# Altium schematic sheet ARDUINORACHX.SchDoc(ARDUINORACHX)
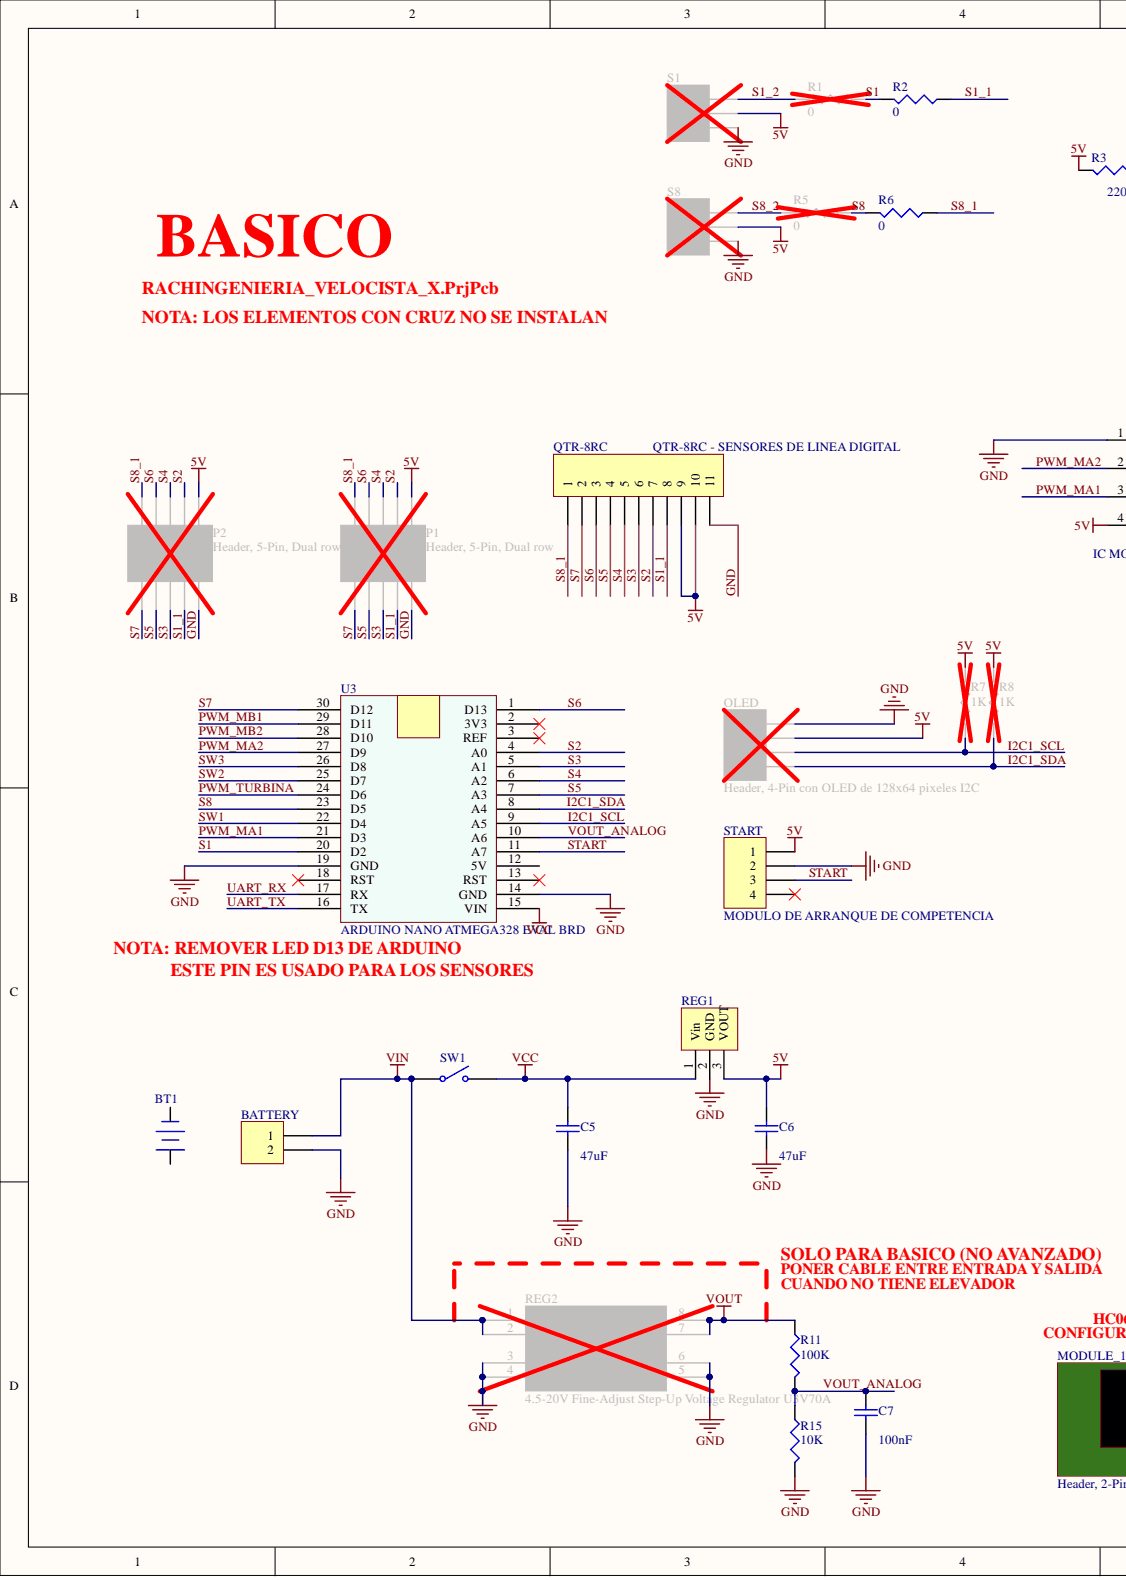
[diagram p0: ARDUINORACHX.SchDoc, left]
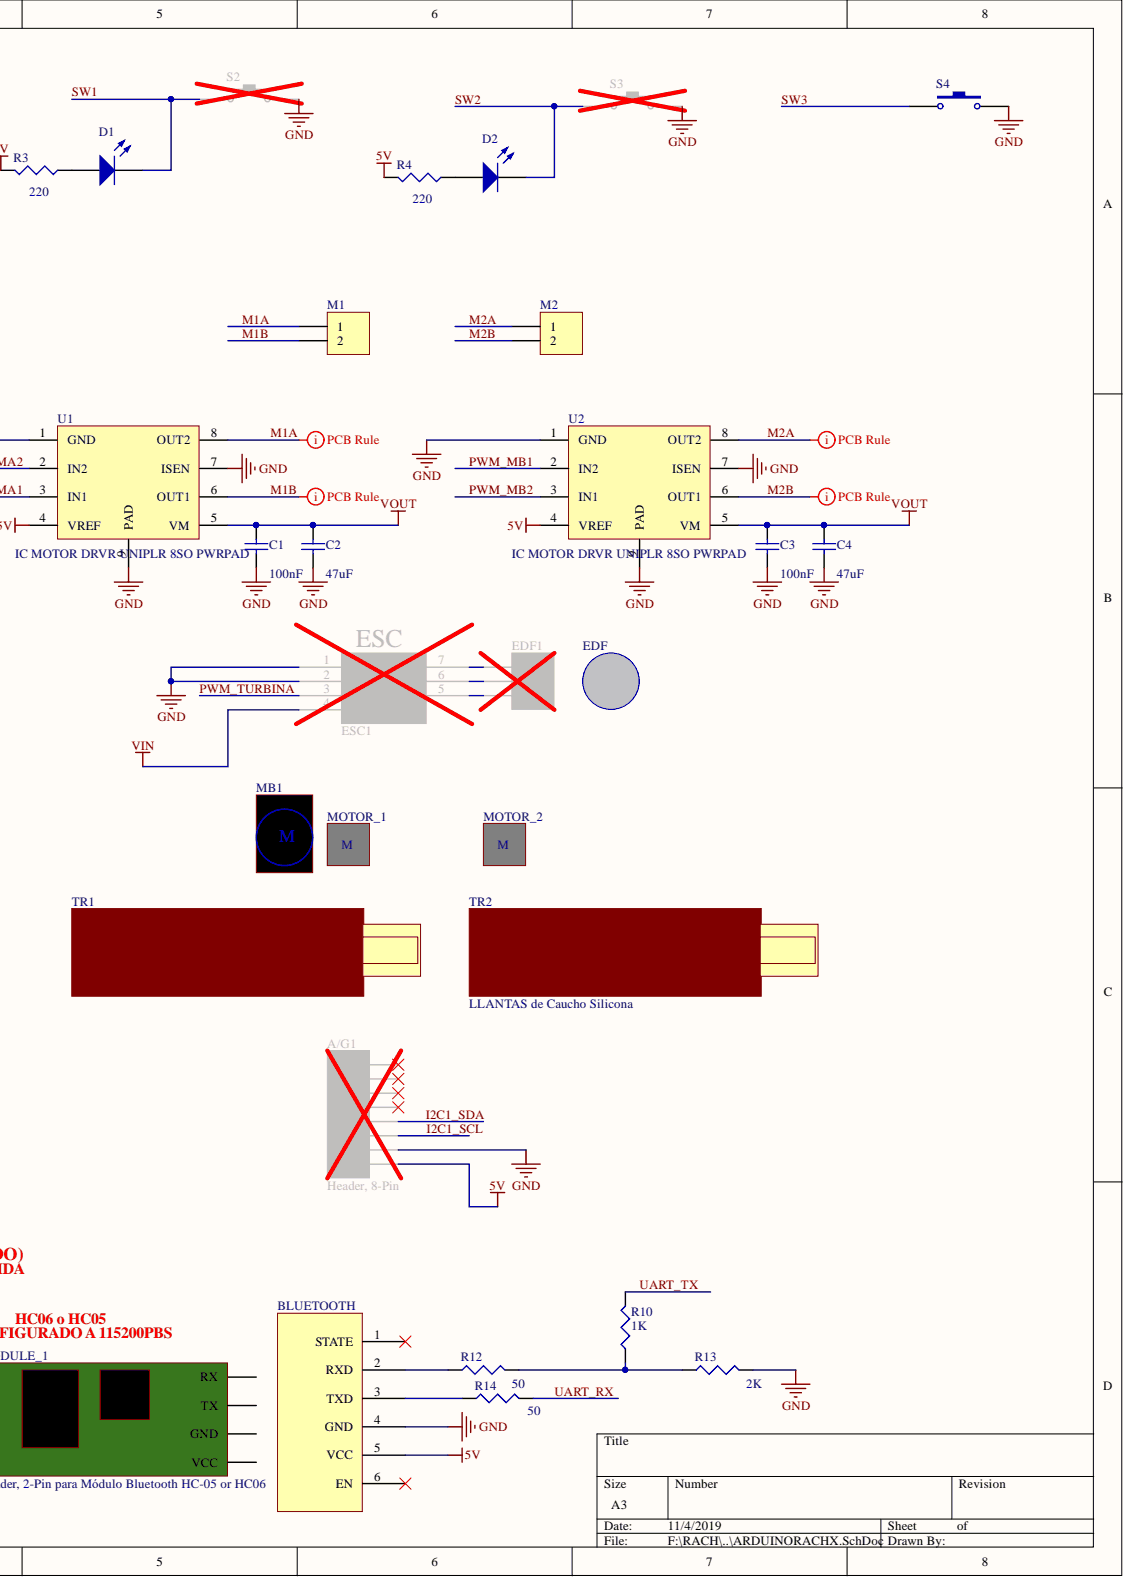
[diagram p1: ARDUINORACHX.SchDoc, right]

## Parts
A/G1  Header, 8-Pin  [ACELEROMETRO]
BATTERY  CONN HEADER VERT 2POS  [HDR1X2]  (value not drawn)
BLUETOOTH  Módulo Bluetooth HC-05 or HC06  [HDR1X6 BT]
BT1  BATERIA LIPO 2s o 3s a 300maH a 2000mAh  [BATERIA]  (value not drawn)
C1  value 100nF  [CC0805]  (hidden comment: CAP CER 0.1UF 50V X7R 0805)
C2  value 47uF  [C1210]  (hidden comment: CAP CER 47UF 25V X5R 1210)
C3  value 100nF  [CC0805]  (hidden comment: CAP CER 0.1UF 50V X7R 0805)
C4  value 47uF  [C1210]  (hidden comment: CAP CER 47UF 25V X5R 1210)
C5  value 47uF  [C1210]  (hidden comment: CAP CER 47UF 25V X5R 1210)
C6  value 47uF  [C1210]  (hidden comment: CAP CER 47UF 25V X5R 1210)
C7  value 100nF  [CC0805]  (hidden comment: CAP CER 0.1UF 50V X7R 0805)
D1  LED RED CLEAR 1206 SMD  [DSO-F2/D6.1]  (value not drawn)
D2  LED RED CLEAR 1206 SMD  [DSO-F2/D6.1]  (value not drawn)
EDF  EDF35 with 11000kv Motor Assembled  [ESC27]  (value not drawn)
EDF1  TURBINA DE 27mm Brushless  [HDR1X3]  (value not drawn)
ESC1  SPEED CONTROLLER de 6 o mas Amperios  [ESC]  (value not drawn)
M1  Pines para Motor  [HDR1X2]  (value not drawn)
M2  Pines para Motor  [HDR1X2]  (value not drawn)
MB1  Pololu Micro Metal Gearmotor Bracket Pair - Black  [TURBINA]  (value not drawn)  (mechanical)
MODULE_1  Header, 2-Pin para Módulo Bluetooth HC-05 or HC06  (mechanical)
MOTOR_1  10:1 Micro Metal Gearmotor HPCB 6V -3000rpm  [MOTORES]  (value not drawn)
MOTOR_2  10:1 Micro Metal Gearmotor HPCB 6V -3000rpm  [MOTORES]  (value not drawn)
OLED  Header, 4-Pin con OLED de 128x64 pixeles I2C  [HDR1X4 OLED]
P1  Header, 5-Pin, Dual row  [HDR2X5]
P2  Header, 5-Pin, Dual row  [HDR2X5]
QTR-8RC  QTR-8RC - SENSORES DE LINEA DIGITAL  [QTR-8RC]
R1  value 0  [CC0805]  (hidden comment: RES SMD 0 OHM 1% 0.4W 0805)
R2  value 0  [CC0805]  (hidden comment: RES SMD 0 OHM 1% 0.4W 0805)
R3  value 220  [CC0805]  (hidden comment: RES SMD 220 OHM 1% 0.4W 0805)
R4  value 220  [CC0805]  (hidden comment: RES SMD 220 OHM 1% 0.4W 0805)
R5  value 0  [CC0805]  (hidden comment: RES SMD 0 OHM 1% 0.4W 0805)
R6  value 0  [CC0805]  (hidden comment: RES SMD 0 OHM 1% 0.4W 0805)
R7  value 1K  [CC0805]  (hidden comment: RES SMD 1K OHM 0.1% 1/8W 0805)
R8  value 1K  [CC0805]  (hidden comment: RES SMD 1K OHM 0.1% 1/8W 0805)
R10  value 1K  [CC0805]  (hidden comment: RES SMD 1K OHM 0.1% 1/8W 0805)
R11  value 100K  [CC0805]  (hidden comment: RES SMD 100K OHM 0.1% 1/8W 0805)
R12  value 50  [CC0805]  (hidden comment: RES SMD 50 OHM 0.05% 1/5W 0805)
R13  value 2K  [CC0805]  (hidden comment: RES SMD 2K OHM 0.1% 1/8W 0805)
R14  value 50  [CC0805]  (hidden comment: RES SMD 50 OHM 0.05% 1/5W 0805)
R15  value 10K  [CC0805]  (hidden comment: RES SMD 10K OHM 1% 0.4W 0805)
REG1  DC DC CONVERTER 5V OR -5V o 7805  [VXO7805]  (value not drawn)
REG2  4.5-20V Fine-Adjust Step-Up Voltage Regulator U3V70A  [StepUpVoltage]
S1  Sensor QTR1 DIGITAL  [HDR1X3]  (value not drawn)
S2  PULSADOR - SWITCH TACTILE SPST-NO 0.05A 12V  [1571563-9]  (value not drawn)
S3  PULSADOR - SWITCH TACTILE SPST-NO 0.05A 12V  [1571563-9]  (value not drawn)
S4  PULSADOR - SWITCH TACTILE SPST-NO 0.05A 12V  [1571563-9]  (value not drawn)
S8  Sensor QTR1 DIGITAL  [HDR1X3]  (value not drawn)
START  MODULO DE ARRANQUE DE COMPETENCIA  [start_module]
SW1  Mini Switch de dos Posiciones  [OS102011MS2QN1]  (value not drawn)
TR1  LLANTAS de Caucho Silicona  (mechanical)
TR2  LLANTAS de Caucho Silicona  (mechanical)
U1  IC MOTOR DRVR UNIPLR 8SO PWRPAD  [SOIC127P600X170_HS-9N]
U2  IC MOTOR DRVR UNIPLR 8SO PWRPAD  [SOIC127P600X170_HS-9N]
U3  ARDUINO NANO ATMEGA328 EVAL BRD  [ARDUINO]

## Nets
5V: A/G1.1 BLUETOOTH.5(VCC) C6.2 OLED.3 P1.10 P2.10 QTR-8RC.9 QTR-8RC.10 R3.2 R4.2 R7.2 R8.2 REG1.3 S1.2 S8.2 START.1 U1.4(VREF) U2.4(VREF)
VCC: C5.2 REG1.1 SW1.2 U3.15(VIN)
VIN: BATTERY.1 ESC1.4(GND) REG2.1(VIIN) REG2.2(VIIN) SW1.1
VOUT: C1.2 C2.2 C3.2 C4.2 R11.1 REG2.7(VOUT) REG2.8(VOUT) U1.5(VM) U2.5(VM)
GND: A/G1.2 BATTERY.2 BLUETOOTH.4(GND) C1.1 C2.1 C3.1 C4.1 C5.1 C6.1 C7.1 ESC1.1(VIN) ESC1.2(GND) OLED.4 P1.9 P2.9 QTR-8RC.11 R13.2 R15.2 REG1.2 REG2.3(GND) REG2.4(GND) REG2.5(GND) REG2.6(GND) S1.1 S2.2 S3.2 S4.2 S8.1 START.2 U1.1(GND) U1.7(ISEN) U1.9(PAD) U2.1(GND) U2.7(ISEN) U2.9(PAD) U3.14(GND) U3.19(GND)
I2C1_SCL: A/G1.3 OLED.2 R7.1 U3.9(A5)
I2C1_SDA: A/G1.4 OLED.1 R8.1 U3.8(A4)
M1A: M1.1 U1.8(OUT2)
M1B: M1.2 U1.6(OUT1)
M2A: M2.1 U2.8(OUT2)
M2B: M2.2 U2.6(OUT1)
PWM_MA1: U1.3(IN1) U3.21(D3)
PWM_MA2: U1.2(IN2) U3.27(D9)
PWM_MB1: U2.2(IN2) U3.29(D11)
PWM_MB2: U2.3(IN1) U3.28(D10)
PWM_TURBINA: ESC1.3(S) U3.24(D6)
S1: R1.2 R2.2 U3.20(D2)
S1_1: P1.7 P2.7 QTR-8RC.8 R2.1
S1_2: R1.1 S1.3
S2: P1.8 P2.8 QTR-8RC.7 U3.4(A0)
S3: P1.5 P2.5 QTR-8RC.6 U3.5(A1)
S4: P1.6 P2.6 QTR-8RC.5 U3.6(A2)
S5: P1.3 P2.3 QTR-8RC.4 U3.7(A3)
S6: P1.4 P2.4 QTR-8RC.3 U3.1(D13)
S7: P1.1 P2.1 QTR-8RC.2 U3.30(D12)
S8: R5.2 R6.2 U3.23(D5)
S8_1: P1.2 P2.2 QTR-8RC.1 R6.1
S8_2: R5.1 S8.3
START: START.3 U3.11(A7)
SW1: D1.2 S2.1 U3.22(D4)
SW2: D2.2 S3.1 U3.25(D7)
SW3: S4.1 U3.26(D8)
UART_RX: R14.2 U3.17(RX)
UART_TX: R10.2 U3.16(TX)
VOUT_ANALOG: C7.2 R11.2 R15.1 U3.10(A6)
NetBLUETOOTH_2: BLUETOOTH.2(RXD) R12.1
NetBLUETOOTH_3: BLUETOOTH.3(TXD) R14.1
NetD1_1: D1.1 R3.1
NetD2_1: D2.1 R4.1
NetEDF1_1: EDF1.1 ESC1.7(X)
NetEDF1_2: EDF1.2 ESC1.6(W)
NetEDF1_3: EDF1.3 ESC1.5(Y)
NetR10_1: R10.1 R12.2 R13.1

Not connected: A/G1 5 6 7 8; BLUETOOTH 1(STATE) 6(EN); MODULE_1 1(RX) 2(TX) 3(GND) 4(VCC); START 4; U3 2(3V3) 3(REF) 12(5V) 13(RST) 18(RST)

## Library data of the parts
A/G1 = Header 8: Manufacturer PN: IMU I2C; Special Notes: OPTIONAL; Signal Integrity: Connector; Manufacturer Part Number 1: IMU I2C; Description: Header, 8-Pin; Component Kind: Standard; Library Name: VELTRONICS.SCHLIB
BATTERY = Header 2: Manufacturer PN: TSW-101-05-G-D; Manufacturer 1: Samtec Inc.; Supplier Part Number 1: SAM1012-01-ND; Supplier 1: Digi-key; Supplier: Digi-key; Signal Integrity: Connector; Manufacturer Part Number 1: TSW-101-05-G-D; Manufacturer: Samtec Inc.; Description: CONN HEADER VERT 2POS; Component Kind: Standard; Library Name: VELTRONICS.SCHLIB
BLUETOOTH = Bluetooth_HC05: CONN HDR 6POS 0.1 GOLD PCB; Manufacturer PN: PPPC061LFBN-RC; Manufacturer 1: Sullins Connector Solutions; Supplier Part Number 1: S7039-ND; Supplier 1: Digi-key; Supplier: Digi-key; Manufacturer Part Number 1: PPPC061LFBN-RC; Manufacturer: Sullins Connector Solutions; Component Kind: Standard; Library Name: VELTRONICS.SCHLIB
BT1 = Battery: Manufacturer PN: LIPO 2S 1000mA; Manufacturer 1: JJTRONICS; Supplier Part Number 1: LIPO 2S 1000mA; Supplier 1: JJTRONICS; Supplier: JJTRONICS; Manufacturer Part Number 1: LIPO 2S 1000mA; Manufacturer: JJTRONICS; Description: BATERIA LIPO 2s o 3s a 300maH a 2000mAh; Component Kind: Standard; Library Name: VELTRONICS.SCHLIB
C1 C3 C7 = Cap: Manufacturer PN: C0805C104K5RACTU; Manufacturer 1: KEMET; Supplier Part Number 1: 399-1170-1-ND; Supplier 1: Digi-key; Supplier: Digi-key; Simulation: CAP; Signal Integrity: Cap; Manufacturer Part Number 1: C0805C104K5RACTU; Manufacturer: KEMET; Description: CAP CER 0.1UF 50V X7R 0805; Component Kind: Standard; Library Name: VELTRONICS.SCHLIB
C2 C4-C6 = Cap: Manufacturer PN: TMK325ABJ476MM-P; Manufacturer 1: Taiyo Yuden; Supplier Part Number 1: 587-5479-1-ND; Supplier 1: Digi-key; Supplier: Digi-key; Simulation: CAP; Signal Integrity: Cap; Manufacturer Part Number 1: TMK325ABJ476MM-P; Manufacturer: Taiyo Yuden; Description: CAP CER 47UF 25V X5R 1210; Component Kind: Standard; Library Name: VELTRONICS.SCHLIB
D1 D2 = LED2: Manufacturer PN: AOL-S12RP4; Manufacturer 1: Digi-key; Manufacturer: Visual Communications Company - VCC; Supplier Part Number 1: VAOL-S12RP4CT-ND; Supplier 1: Digi-key; Supplier: Digi-key; Simulation: LED2; Manufacturer Part Number 1: AOL-S12RP4; Description: LED RED CLEAR 1206 SMD; Component Kind: Standard; Library Name: VELTRONICS.SCHLIB
EDF = EDF TURBINA: Manufacturer 1: HobbyKing; Supplier Part Number 1: EDF35 with 11000kv Motor Assembled; Supplier 1: HobbyKing; Supplier: HobbyKing; Manufacturer PN: EDF35 with 11000kv Motor Assembled; Manufacturer Part Number 1: EDF35 with 11000kv Motor Assembled; Manufacturer: HobbyKing; Description: EDF35 with 11000kv Motor Assembled; Component Kind: Standard; Library Name: VELTRONICS.SCHLIB
EDF1 S1 S8 = Header 3: Special Notes: Not Part; Signal Integrity: Connector; Manufacturer PN: Not Part; Manufacturer Part Number 1: Not Part; Component Kind: Standard; Library Name: VELTRONICS.SCHLIB
  EDF1: Description: TURBINA DE 27mm Brushless
  S1 S8: Description: Sensor QTR1 DIGITAL
ESC1 = ESC_30: Manufacturer PN: ESC 20A Mini; Manufacturer 1: JJTRONICS; Supplier Part Number 1: ESC 20A Mini; Supplier 1: JJTRONICS; Supplier: JJTRONICS; Manufacturer Part Number 1: ESC 20A Mini; Manufacturer: JJTRONICS; Description: SPEED CONTROLLER de 6 o mas Amperios; Component Kind: Standard; Library Name: RACHINGENIERIA_VELOCISTA_X.SCHLIB
M1 M2 = Header 2: Special Notes: Not Part; Signal Integrity: Connector; Manufacturer PN: Not Part; Manufacturer Part Number 1: Not Part; Description: Pines para Motor; Component Kind: Standard; Library Name: VELTRONICS.SCHLIB
MB1 = MotorBrakets: Manufacturer PN: 989; Supplier Part Number 1: 989; Supplier 1: POLOLU; Supplier: POLOLU; Manufacturer Part Number 1: 989; Manufacturer 1: POLOLU; Manufacturer: POLOLU; Description: Pololu Micro Metal Gearmotor Bracket Pair - Black; Library Name: VELTRONICS.SCHLIB
MODULE_1 = HC05: Módulo Bluetooth HC-05 or HC06; Manufacturer PN: HC-05 / HC06; Manufacturer 1: JJTRONICS; Supplier Part Number 1: HC-05 / HC06; Supplier 1: JJTRONICS; Supplier: JJTRONICS; Signal Integrity: Connector; Manufacturer Part Number 1: HC-05 / HC06; Manufacturer: JJTRONICS; Library Name: VELTRONICS.SCHLIB
MOTOR_1 MOTOR_2 = MOTORES: Manufacturer PN: 236; Manufacturer 1: POLOLU; Supplier Part Number 1: 236; Supplier 1: POLOLU; Supplier: POLOLU; Signal Integrity: Connector; Manufacturer Part Number 1: 236; Manufacturer: POLOLU; Description: 10:1 Micro Metal Gearmotor HPCB 6V -3000rpm; Component Kind: Standard; Library Name: VELTRONICS.SCHLIB
OLED = Header 4: Manufacturer PN: OLED I2C; Manufacturer 1: JJTRONICS; Signal Integrity: Connector; Manufacturer Part Number 1: OLED I2C; Manufacturer: JJTRONICS; Description: Header, 4-Pin con OLED de 128x64 pixeles I2C; Component Kind: Standard; Library Name: VELTRONICS.SCHLIB
P1 P2 = Header 5X2: Special Notes: OPTIONAL; Signal Integrity: Connector; Manufacturer PN: HDR2X5; Manufacturer Part Number 1: HDR2X5; Description: Header, 5-Pin, Dual row; Component Kind: Standard; Library Name: VELTRONICS.SCHLIB
QTR-8RC = Header 11H: Manufacturer 1: JJTRONICS; Supplier Part Number 1: QTR-8RC; Supplier 1: JJTRONICS; Supplier: JJTRONICS; Signal Integrity: Connector; Manufacturer PN: QTR-8RC; Manufacturer Part Number 1: QTR-8RC; Manufacturer: JJTRONICS; Description: QTR-8RC - SENSORES DE LINEA DIGITAL; Component Kind: Standard; Library Name: VELTRONICS.SCHLIB
R1 R2 R5 R6 = Res3: Manufacturer PN: ESR10EZPF0; Manufacturer 1: Rohm Semiconductor; Manufacturer: Resistencia; Supplier Part Number 1: RHM0AECT-ND; Supplier 1: Digi-key; Supplier: Digi-key; Simulation: RESISTOR; Signal Integrity: Res; Manufacturer Part Number 1: ESR10EZPF0; Description: RES SMD 0 OHM 1% 0.4W 0805; Component Kind: Standard; Library Name: VELTRONICS.SCHLIB
R3 R4 = Res3: Manufacturer PN: ESR10EZPF2200; Manufacturer 1: Rohm Semiconductor; Manufacturer: Resistencia; Supplier Part Number 1: RHM220AECT-ND; Supplier 1: Digi-key; Supplier: Digi-key; Simulation: RESISTOR; Signal Integrity: Res; Manufacturer Part Number 1: ESR10EZPF2200; Description: RES SMD 220 OHM 1% 0.4W 0805; Component Kind: Standard; Library Name: VELTRONICS.SCHLIB
R7 R8 R10 = Res3: Manufacturer PN: ERA-6AEB102V; Manufacturer 1: Panasonic Electronic Components; Manufacturer: Resistencia; Supplier Part Number 1: P1.0KDACT-ND; Supplier 1: Digi-key; Supplier: Digi-key; Simulation: RESISTOR; Signal Integrity: Res; Manufacturer Part Number 1: ERA-6AEB102V; Description: RES SMD 1K OHM 0.1% 1/8W 0805; Component Kind: Standard; Library Name: VELTRONICS.SCHLIB
R11 = Res3: Manufacturer PN: ERA-6AEB104V; Manufacturer 1: Panasonic Electronic Components; Manufacturer: Resistencia; Supplier Part Number 1: P100KDACT-ND; Supplier 1: Digi-key; Supplier: Digi-key; Simulation: RESISTOR; Signal Integrity: Res; Manufacturer Part Number 1: ERA-6AEB104V; Description: RES SMD 100K OHM 0.1% 1/8W 0805; Component Kind: Standard; Library Name: VELTRONICS.SCHLIB
R12 = Res3: Manufacturer PN: Y402250R0000A9R; Manufacturer 1: Rohm Semiconductor; Manufacturer: Resistencia; Supplier Part Number 1: 804-1106-1-ND; Supplier 1: Digi-key; Supplier: Digi-key; Simulation: RESISTOR; Signal Integrity: Res; Manufacturer Part Number 1: Y402250R0000A9R; Description: RES SMD 50 OHM 0.05% 1/5W 0805; Component Kind: Standard; Library Name: VELTRONICS.SCHLIB
R13 = Res3: Manufacturer PN: ERA-6AEB202V; Manufacturer 1: Panasonic Electronic Components; Manufacturer: Resistencia; Supplier Part Number 1: P2.0KDACT-ND; Supplier 1: Digi-key; Supplier: Digi-key; Simulation: RESISTOR; Signal Integrity: Res; Manufacturer Part Number 1: ERA-6AEB202V; Description: RES SMD 2K OHM 0.1% 1/8W 0805; Component Kind: Standard; Library Name: VELTRONICS.SCHLIB
R14 = Res3: Manufacturer PN: Y402250R0000A9R; Manufacturer 1: Vishay Foil Resistors (Division of Vishay Precision Group); Manufacturer: Resistencia; Supplier Part Number 1: 804-1106-1-ND; Supplier 1: Digi-key; Supplier: Digi-key; Simulation: RESISTOR; Signal Integrity: Res; Manufacturer Part Number 1: Y402250R0000A9R; Description: RES SMD 50 OHM 0.05% 1/5W 0805; Component Kind: Standard; Library Name: VELTRONICS.SCHLIB
R15 = Res3: Manufacturer PN: ERA-6AEB103V; Manufacturer 1: Panasonic Electronic Components; Manufacturer: Resistencia; Supplier Part Number 1: P10KDACT-ND; Supplier 1: Digi-key; Supplier: Digi-key; Simulation: RESISTOR; Signal Integrity: Res; Manufacturer Part Number 1: ERA-6AEB103V; Description: RES SMD 10K OHM 1% 0.4W 0805; Component Kind: Standard; Library Name: VELTRONICS.SCHLIB
REG1 = VxO7805: Manufacturer PN: VXO7805-500; Manufacturer 1: CUI Inc.; Supplier Part Number 1: 102-4249-ND; Supplier 1: Digi-Key; Supplier: Digi-Key; Signal Integrity: Connector; Manufacturer Part Number 1: VXO7805-500; Manufacturer: CUI Inc.; Description: DC DC CONVERTER 5V OR -5V o 7805; Component Kind: Standard; Library Name: VELTRONICS.SCHLIB
REG2 = StepUp: Manufacturer PN: 2890; Manufacturer 1: POLOLU; Supplier Part Number 1: 2890; Supplier 1: POLOLU; Supplier: POLOLU; Manufacturer Part Number 1: 2890; Manufacturer: POLOLU; Description: 4.5-20V Fine-Adjust Step-Up Voltage Regulator U3V70A; Component Kind: Standard; Library Name: VELTRONICS.SCHLIB
S2-S4 = SW-PB: Manufacturer PN: TL59NF160Q; Manufacturer 1: E-Switch; Supplier Part Number 1: EG2532CT-ND; Supplier 1: Digi-key; Supplier: Digi-key; Manufacturer Part Number 1: TL59NF160Q; Manufacturer: E-Switch; Description: PULSADOR - SWITCH TACTILE SPST-NO 0.05A 12V; Component Kind: Standard; Library Name: VELTRONICS.SCHLIB
START = Header 4: Manufacturer PN: START ROBOT MODULE; Manufacturer 1: JJTRONICS; Supplier Part Number 1: START ROBOT MODULE; Supplier 1: JJTRONICS; Supplier: JJTRONICS; Signal Integrity: Connector; Manufacturer Part Number 1: START ROBOT MODULE; Manufacturer: JJTRONICS; Description: MODULO DE ARRANQUE DE COMPETENCIA; Component Kind: Standard; Library Name: VELTRONICS.SCHLIB
SW1 = SW-SPST: Manufacturer PN: SS-12D07-VG 4 NS GA PA; Manufacturer 1: C&K; Supplier Part Number 1: CKN10397-ND; Supplier 1: Digi-key; Supplier: Digi-key; Manufacturer Part Number 1: SS-12D07-VG 4 NS GA PA; Manufacturer: C&K; Description: Mini Switch de dos Posiciones; Component Kind: Standard; Library Name: VELTRONICS.SCHLIB
TR1 TR2 = LLANTAS: Manufacturer PN: R2cmx3cm; Manufacturer 1: Rachingenieria.x; Supplier Part Number 1: R2cmx3cm; Supplier 1: Rachingenieria.x; Supplier: Rachingenieria.x; Manufacturer Part Number 1: R2cmx3cm; Manufacturer: LLANTAS; Description: LLANTAS de Caucho Silicona; Library Name: VELTRONICS.SCHLIB
U1 U2 = DRV8870: Manufacturer PN: DRV8870DDAR; Manufacturer 1: Texas Instruments; Supplier Part Number 1: 296-42660-1-ND; Supplier 1: Digi-key; Supplier: Digi-key; Manufacturer Part Number 1: DRV8870DDAR; Manufacturer: Texas Instruments; Description: IC MOTOR DRVR UNIPLR 8SO PWRPAD; Component Kind: Standard; Library Name: VELTRONICS.SCHLIB
U3 = ARDUINO NANO: Manufacturer PN: A000005; Supplier Part Number 1: 1050-1001-ND; Supplier 1: 1050-1001-ND; Supplier: Digi-key; Manufacturer Part Number 1: A000005; Manufacturer 1: Arduino; Manufacturer: Arduino; Description: ARDUINO NANO ATMEGA328 EVAL BRD; Component Kind: Standard; Library Name: VELTRONICS.SCHLIB
